# Altium schematic sheet Opamps.SchDoc
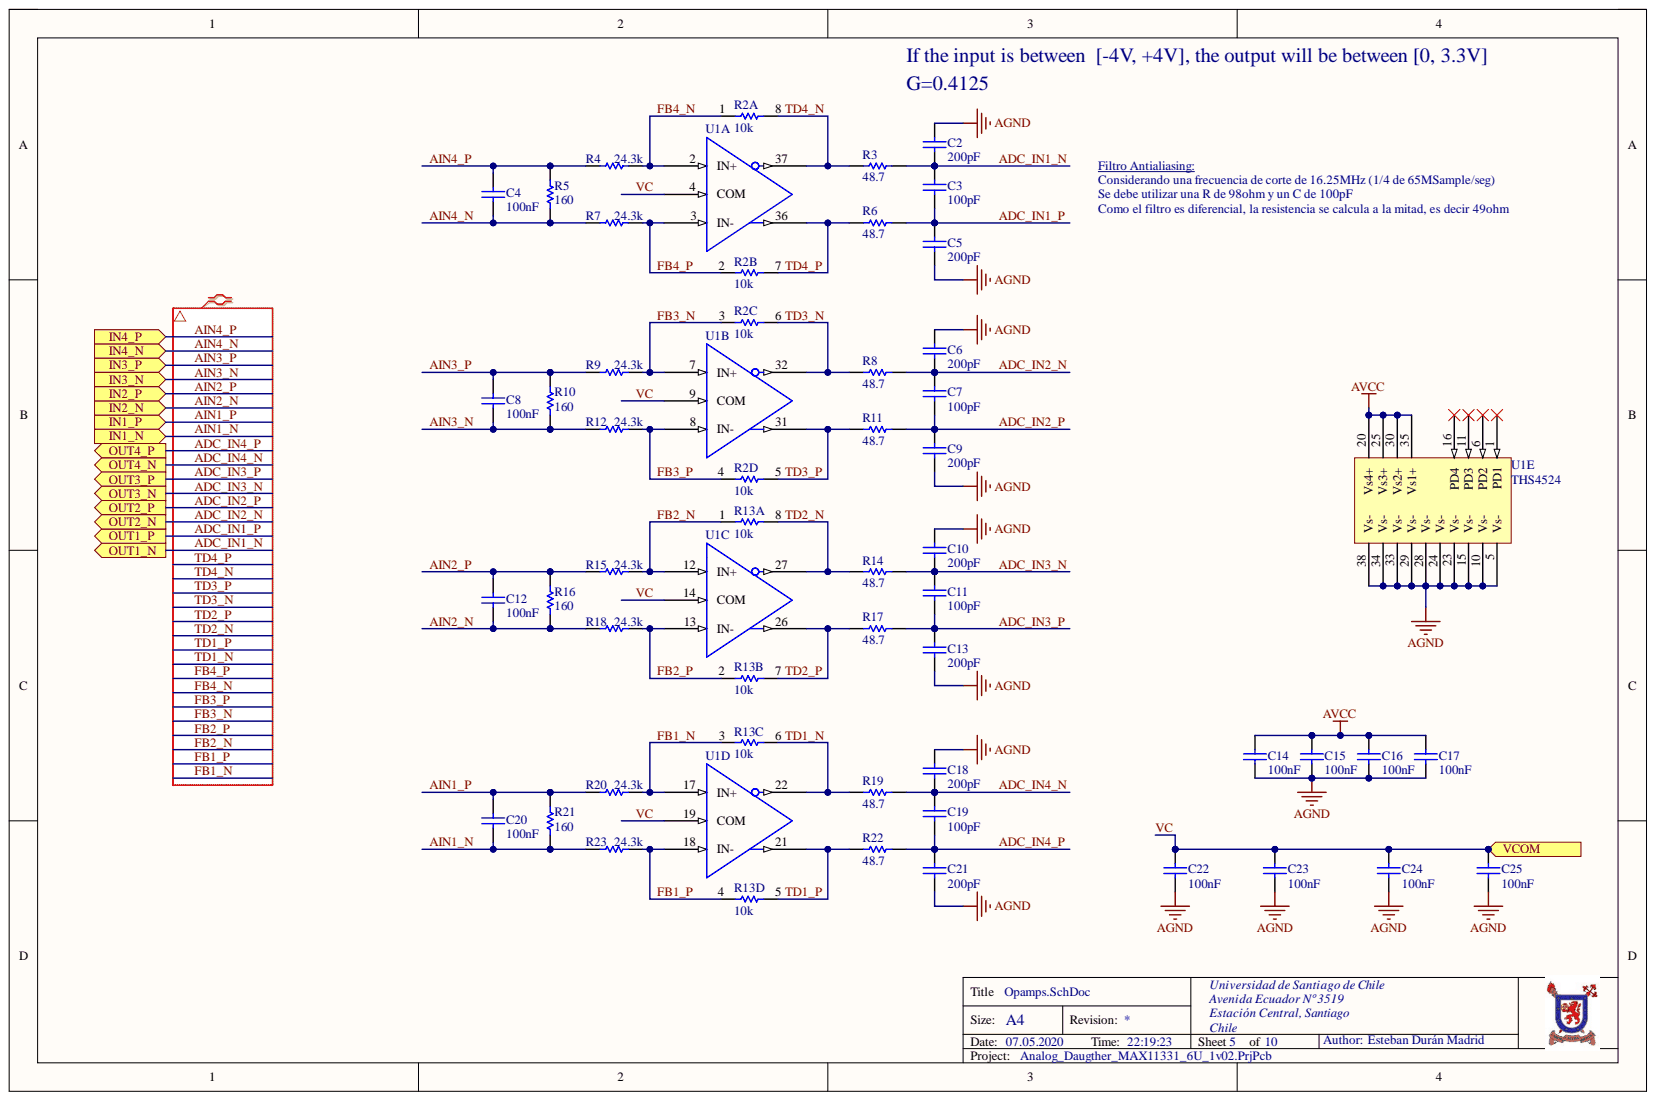
[diagram p0: Opamps.SchDoc]

## Parts
C2  200pF  [CAP_0603]
C3  100pF  [CAP_0603]
C4  100nF  [RES_1206]
C5  200pF  [CAP_0603]
C6  200pF  [CAP_0603]
C7  100pF  [CAP_0603]
C8  100nF  [RES_1206]
C9  200pF  [CAP_0603]
C10  200pF  [CAP_0603]
C11  100pF  [CAP_0603]
C12  100nF  [RES_1206]
C13  200pF  [CAP_0603]
C14  100nF  [CAP_0603]
C15  100nF  [CAP_0603]
C16  100nF  [CAP_0603]
C17  100nF  [CAP_0603]
C18  200pF  [CAP_0603]
C19  100pF  [CAP_0603]
C20  100nF  [RES_1206]
C21  200pF  [CAP_0603]
C22  100nF  [CAP_0603]
C23  100nF  [CAP_0603]
C24  100nF  [CAP_0603]
C25  100nF  [CAP_0603]
R2  10k  [CAC-80P320X160-8N]  (parts A,B,C,D)
R3  48.7  [RES_0603]
R4  24.3k  [RES_0603]
R5  160  [RES_1206]
R6  48.7  [RES_0603]
R7  24.3k  [RES_0603]
R8  48.7  [RES_0603]
R9  24.3k  [RES_0603]
R10  160  [RES_1206]
R11  48.7  [RES_0603]
R12  24.3k  [RES_0603]
R13  10k  [CAC-80P320X160-8N]  (parts A,B,C,D)
R14  48.7  [RES_0603]
R15  24.3k  [RES_0603]
R16  160  [RES_1206]
R17  48.7  [RES_0603]
R18  24.3k  [RES_0603]
R19  48.7  [RES_0603]
R20  24.3k  [RES_0603]
R21  160  [RES_1206]
R22  48.7  [RES_0603]
R23  24.3k  [RES_0603]
U1  THS4524  [THS4524 Footprint]  (parts A,B,C,D,E)

## Ports
IN1_N, IN1_P, IN2_N, IN2_P, IN3_N, IN3_P, IN4_N, IN4_P, OUT1_N, OUT1_P, OUT2_N, OUT2_P, OUT3_N, OUT3_P, OUT4_N, OUT4_P, VCOM

## Nets
AGND: C2.1 C5.2 C6.1 C9.2 C10.1 C13.2 C14.2 C15.2 C16.2 C17.2 C18.1 C21.2 C22.1 C23.1 C24.1 C25.1 U1.5(Vs-) U1.10(Vs-) U1.15(Vs-) U1.23(Vs-) U1.24(Vs-) U1.28(Vs-) U1.29(Vs-) U1.33(Vs-) U1.34(Vs-) U1.38(Vs-)
AVCC: C14.1 C15.1 C16.1 C17.1 U1.20(Vs4+) U1.25(Vs3+) U1.30(Vs2+) U1.35(Vs1+)
ADC_IN1_N: C2.2 C3.1 R3.1 ; port OUT1_N
ADC_IN1_P: C3.2 C5.1 R6.1 ; port OUT1_P
ADC_IN2_N: C6.2 C7.1 R8.1 ; port OUT2_N
ADC_IN2_P: C7.2 C9.1 R11.1 ; port OUT2_P
ADC_IN3_N: C10.2 C11.1 R14.1 ; port OUT3_N
ADC_IN3_P: C11.2 C13.1 R17.1 ; port OUT3_P
ADC_IN4_N: C18.2 C19.1 R19.1 ; port OUT4_N
ADC_IN4_P: C19.2 C21.1 R22.1 ; port OUT4_P
AIN1_N: C20.2 R21.2 R23.2 ; port IN1_N
AIN1_P: C20.1 R20.2 R21.1 ; port IN1_P
AIN2_N: C12.2 R16.2 R18.2 ; port IN2_N
AIN2_P: C12.1 R15.2 R16.1 ; port IN2_P
AIN3_N: C8.2 R10.2 R12.2 ; port IN3_N
AIN3_P: C8.1 R9.2 R10.1 ; port IN3_P
AIN4_N: C4.2 R5.2 R7.2 ; port IN4_N
AIN4_P: C4.1 R4.2 R5.1 ; port IN4_P
FB1_N: R13.3 R20.1 U1.17(IN+)
FB1_P: R13.4 R23.1 U1.18(IN-)
FB2_N: R13.1 R15.1 U1.12(IN+)
FB2_P: R13.2 R18.1 U1.13(IN-)
FB3_N: R2.3 R9.1 U1.7(IN+)
FB3_P: R2.4 R12.1 U1.8(IN-)
FB4_N: R2.1 R4.1 U1.2(IN+)
FB4_P: R2.2 R7.1 U1.3(IN-)
TD1_N: R13.6 R19.2 U1.22
TD1_P: R13.5 R22.2 U1.21
TD2_N: R13.8 R14.2 U1.27
TD2_P: R13.7 R17.2 U1.26
TD3_N: R2.6 R8.2 U1.32
TD3_P: R2.5 R11.2 U1.31
TD4_N: R2.8 R3.2 U1.37
TD4_P: R2.7 R6.2 U1.36
VC: C22.2 C23.2 C24.2 C25.2 U1.4(COM) U1.9(COM) U1.14(COM) U1.19(COM) ; port VCOM

Not connected: U1 1(PD1) 6(PD2) 11(PD3) 16(PD4)

## Library data of the parts
C2 C5 C6 C9 C10 C13 C18 C21 = Cap_0603: Capacitor; Manufacturer Part-Number: CL10B201KB8NNNC; Rule: PcbType=ProtelPcb; Component Kind: Standard; Digikey Part-Number: 1276-1982-1-ND; LatestRevisionDate: 17-Jul-2002; LatestRevisionNote: Re-released for DXP Platform.; PackageReference: RAD-0.3; Published: 8-Jun-2000; Signal Integrity: Cap; Simulation: CAP; Value: 200pF; Library Name: Componentes_SOC.SchLib; Publisher: Altium Limited
C3 C7 C11 C19 = Cap_0603: Capacitor; Manufacturer Part-Number: 06031A101FAT2A; Rule: PcbType=ProtelPcb; Component Kind: Standard; Digikey Part-Number: 478-3671-1-ND; LatestRevisionDate: 17-Jul-2002; LatestRevisionNote: Re-released for DXP Platform.; PackageReference: RAD-0.3; Published: 8-Jun-2000; Signal Integrity: Cap; Simulation: CAP; Value: 100pF; Library Name: Componentes_SOC.SchLib; Publisher: Altium Limited
C4 C8 C12 C20 = Cap_1206: Capacitor; Component Kind: Standard; Signal Integrity: Cap; Simulation: CAP; Value: 100nF; Library Name: Componentes_SOC.SchLib
C14-C17 C22-C25 = Cap_0603: Capacitor; Manufacturer Part-Number: LD03YC104KAB2A; Rule: PcbType=ProtelPcb; Component Kind: Standard; Digikey Part-Number: 478-4929-1-ND; LatestRevisionDate: 17-Jul-2002; LatestRevisionNote: Re-released for DXP Platform.; PackageReference: RAD-0.3; Published: 8-Jun-2000; Signal Integrity: Cap; Simulation: CAP; Value: 100nF; Library Name: Componentes_SOC.SchLib; Publisher: Altium Limited
R2 R13 = ResArray 1206: Chip Resistor Array 0606, 4xR; MPN: ACASA1002S1002P100; MF: Vishay; Component Kind: Standard; Supplier 1: Digikey; Supplier Part Number 1: 749-1023-1-ND; Value: 10k; Created: Kreppel_0420; Library Name: Componentes_SOC.SchLib
R3 R6 R8 R11 R14 R17 R19 R22 = Res0603: Manufacturer Part-Number: RMCF0603FT48R7; Component Kind: Standard; Digikey Part-Number: RMCF0603FT48R7CT-ND; LatestRevisionDate: 17-Jul-2002; LatestRevisionNote: Re-released for DXP Platform.; PackageDescription: Axial Device, Thru-Hole; 2 Leads; 0.3 in Pin Spacing; PackageReference: AXIAL-0.3; Published: 8-Jun-2000; Signal Integrity: Res; Simulation: RESISTOR; Value: 48.7; Library Name: Componentes_SOC.SchLib; Publisher: Altium Limited
R4 R7 R9 R12 R15 R18 R20 R23 = Res0603: Manufacturer Part-Number: ERA-3AEB2432V; Component Kind: Standard; Digikey Part-Number: P24.3KDBCT-ND; LatestRevisionDate: 17-Jul-2002; LatestRevisionNote: Re-released for DXP Platform.; PackageDescription: Axial Device, Thru-Hole; 2 Leads; 0.3 in Pin Spacing; PackageReference: AXIAL-0.3; Published: 8-Jun-2000; Signal Integrity: Res; Simulation: RESISTOR; Value: 24.3k; Library Name: Componentes_SOC.SchLib; Publisher: Altium Limited
R5 R10 R16 R21 = Res Semi: Limiter Resistor; Manufacturer Part-Number: ERA-8AEB161V; Component Kind: Standard; Digikey Part-Number: P160BCCT-ND; LatestRevisionDate: 17-Jul-2002; LatestRevisionNote: Re-released for DXP Platform.; Library Name: Miscellaneous Devices.IntLib; PackageDescription: Axial Device, Thru-Hole; 2 Leads; 0.5 in Pin Spacing; PackageReference: AXIAL-0.5; Published: 8-Jun-2000; Signal Integrity: Res Semi; Simulation: RES; Value: 160; Publisher: Altium Limited
U1 = THS4524_1: Quad Channel fully differential Amplilfier; MF: Texas Instruments; Component Kind: Standard; MPN: THS4524; Package: TSSOP-38; Supplier 1: Mouser; Supplier Part Number 1: 595-THS4524IDBTR; Created: 20190720_Kreppel; Library Name: Componentes_SOC.SchLib
